# Altium schematic sheet power.SchDoc(power)
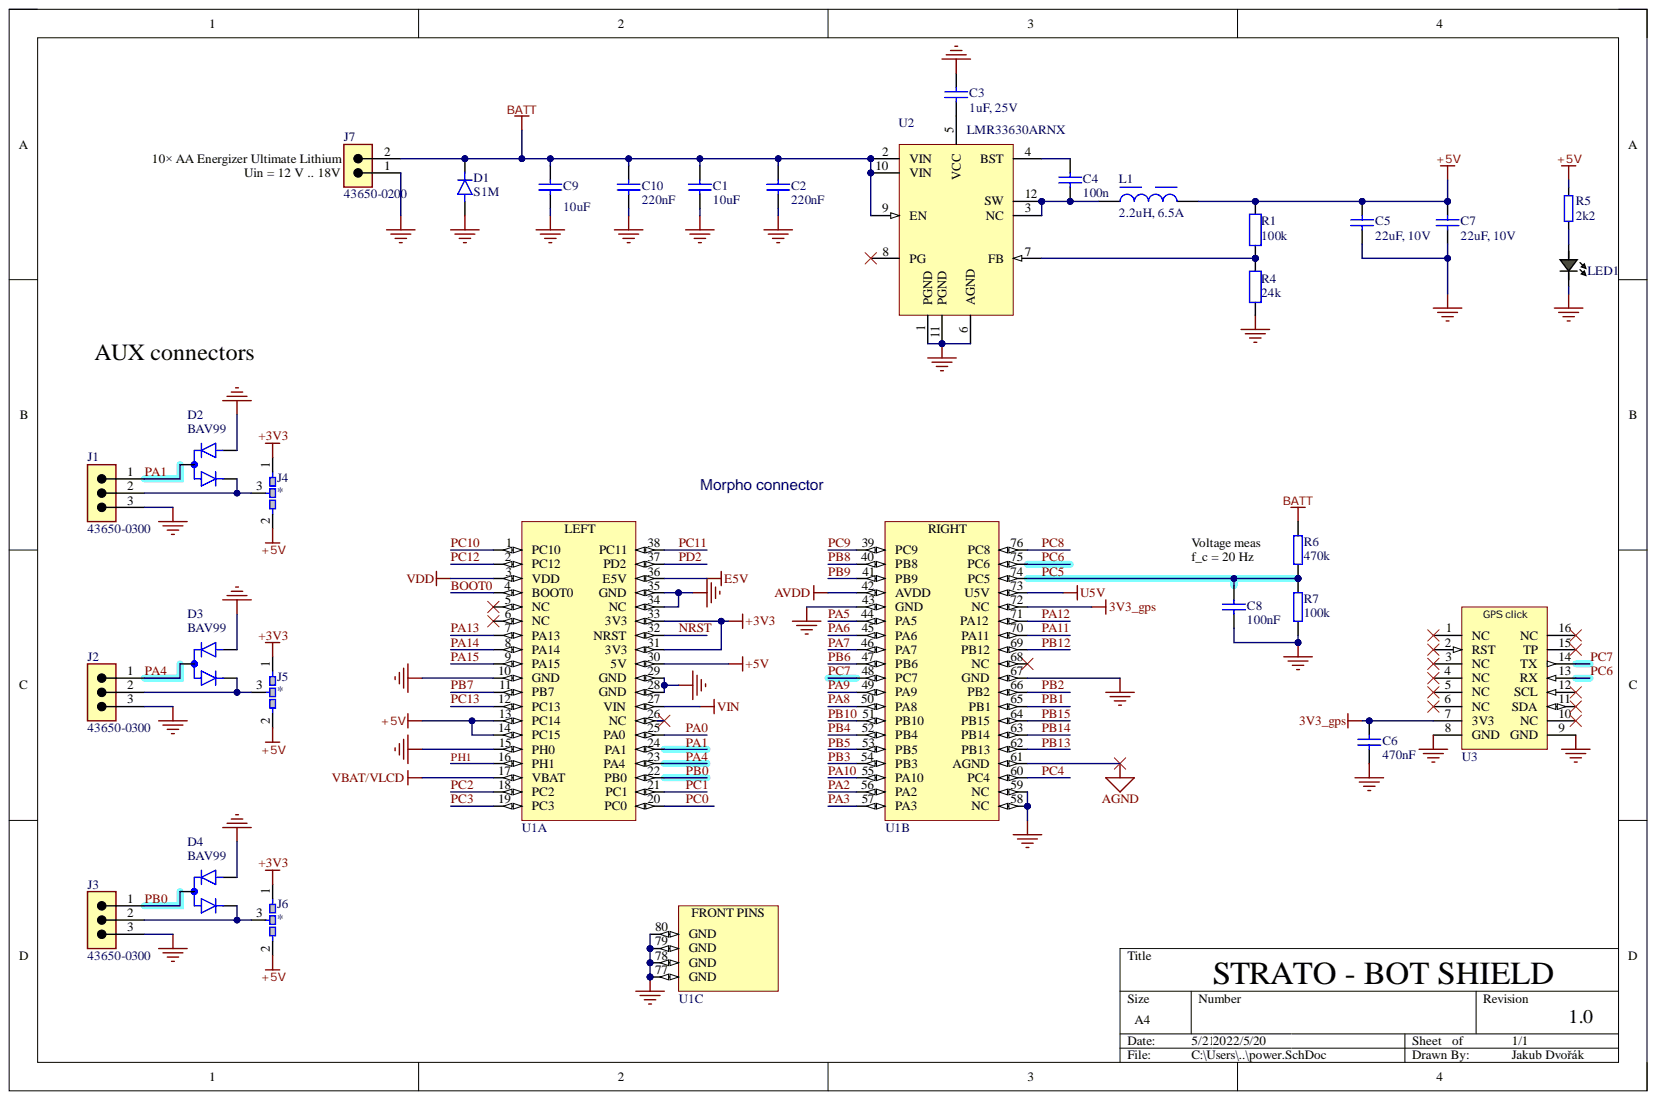
[diagram p0: power.SchDoc]

## Parts
C1  10uF  [WCAP-CSGP_1206_L3.2W1.6H1.6]
C2  220nF  [WCAP-CSGP_0603_L1.6W0.8H0.8_Reflow]
C3  1uF, 25V  [WCAP-CSGP_0603_L1.6W0.8H0.8_Reflow]
C4  100n  [WCAP-CSGP_0603_L1.6W0.8H0.8_Reflow]
C5  22uF, 10V  [WCAP-CSGP_0805_L2W1.25H1.25]
C6  470nF  [WCAP-CSGP_0805_L2W1.25H1.25]
C7  22uF, 10V  Rated Voltage: 10V  [WCAP-CSGP_0805_L2W1.25H1.25]
C8  100nF  [WCAP-CSGP_0805_L2W1.25H0.8_Reflow]
C9  10uF  [WCAP-CSGP_1206_L3.2W1.6H1.6]
C10  220nF  [WCAP-CSGP_0603_L1.6W0.8H0.8_Reflow]
D1  S1M  [DIOM5127X23N(SMA)]
D2  BAV99  [SOT95P230X110-3N(SOT23)]
D3  BAV99  [SOT95P230X110-3N(SOT23)]
D4  BAV99  [SOT95P230X110-3N(SOT23)]
J1  43650-0300  [MOLEX_43650-0300]
J2  43650-0300  [MOLEX_43650-0300]
J3  43650-0300  [MOLEX_43650-0300]
J4  (no value)  [JUMPER3_SMT_1LAYER]  (no BOM)
J5  (no value)  [JUMPER3_SMT_1LAYER]  (no BOM)
J6  (no value)  [JUMPER3_SMT_1LAYER]  (no BOM)
J7  43650-0200  [MOLEX_43650-0200]
L1  2.2uH, 6.5A  Rated Current: 6.5A  [WE-LHMI_7030]
LED1  green  [0805LEDG]  (value not drawn)
R1  value 100k  [RES_0603]  (hidden comment: Thick film)
R4  value 24k  [RES_0603]  (hidden comment: Thick film)
R5  value 2k2  [RES_0603]  (hidden comment: Thick film)
R6  470k  [RESC2012X06N(SMR0805)]
R7  100k  [RESC2012X06N(SMR0805)]
U1  NUCLEO F466 MORPHO LEFT  [Nucleo_bot_pads]  (value not drawn)  (parts A,B,C)
U2  LMR33630ARNX  [QFN50P200X300X100-12N]
U3  GPS Click  [GPS click - no legs]  (value not drawn)

## Nets
3V3_gps: C6.2 J4.1 J5.1 J6.1 U1.31(3V3) U1.33(3V3) U1.72(NC) U3.7(3V3)
+5V: C5.2 C7.2 J4.2 J5.2 J6.2 L1.2 R1.2 R5.2 U1.13(PC14) U1.14(PC15) U1.30(5V)
AGND: U1.61(AGND)
AVDD: U1.42(AVDD)
BATT: C1.2 C2.2 C9.2 C10.2 D1.1 J7.2 R6.2 U2.2(VIN) U2.9(EN) U2.10(VIN)
E5V: U1.36(E5V)
GND: C1.1 C2.1 C3.2 C5.1 C6.1 C7.1 C8.1 C9.1 C10.1 D1.2 D2.1 D3.1 D4.1 J1.3 J2.3 J3.3 J7.1 LED1.K R4.1 R7.1 U1.10(GND) U1.15(PH0) U1.28(GND) U1.29(GND) U1.34(NC) U1.35(GND) U1.43(GND) U1.58(NC) U1.59(NC) U1.67(GND) U1.77(GND) U1.78(GND) U1.79(GND) U1.80(GND) U2.1(PGND) U2.6(AGND) U2.11(PGND) U3.8(GND) U3.9(GND)
U5V: U1.73(U5V)
VBAT/VLCD: U1.17(VBAT)
VDD: U1.3(VDD)
VIN: U1.27(VIN)
BOOT0: U1.4(BOOT0)
NRST: U1.32(NRST)
PA0: U1.25(PA0)
PA1: D2.3 J1.1 U1.24(PA1)
PA2: U1.56(PA2)
PA3: U1.57(PA3)
PA4: D3.3 J2.1 U1.23(PA4)
PA5: U1.44(PA5)
PA6: U1.45(PA6)
PA7: U1.46(PA7)
PA8: U1.50(PA8)
PA9: U1.49(PA9)
PA10: U1.55(PA10)
PA11: U1.70(PA11)
PA12: U1.71(PA12)
PA13: U1.7(PA13)
PA14: U1.8(PA14)
PA15: U1.9(PA15)
PB0: D4.3 J3.1 U1.22(PB0)
PB1: U1.65(PB1)
PB2: U1.66(PB2)
PB3: U1.54(PB3)
PB4: U1.52(PB4)
PB5: U1.53(PB5)
PB6: U1.47(PB6)
PB7: U1.11(PB7)
PB8: U1.40(PB8)
PB9: U1.41(PB9)
PB10: U1.51(PB10)
PB12: U1.69(PB12)
PB13: U1.62(PB13)
PB14: U1.63(PB14)
PB15: U1.64(PB15)
PC0: U1.20(PC0)
PC1: U1.21(PC1)
PC2: U1.18(PC2)
PC3: U1.19(PC3)
PC4: U1.60(PC4)
PC5: C8.2 R6.1 R7.2 U1.74(PC5)
PC6: U1.75(PC6) U3.13(RX)
PC7: U1.48(PC7) U3.14(TX)
PC8: U1.76(PC8)
PC9: U1.39(PC9)
PC10: U1.1(PC10)
PC11: U1.38(PC11)
PC12: U1.2(PC12)
PC13: U1.12(PC13)
PD2: U1.37(PD2)
PH1: U1.16(PH1)
NetC3_1: C3.1 U2.5(VCC)
NetC4_1: C4.1 L1.1 U2.3(NC) U2.12(SW)
NetC4_2: C4.2 U2.4(BST)
NetD2_2: D2.2 J1.2 J4.3
NetD3_2: D3.2 J2.2 J5.3
NetD4_2: D4.2 J3.2 J6.3
NetLED1_A: LED1.A R5.1
NetR1_1: R1.1 R4.2 U2.7(FB)

Not connected: U1 5(NC) 6(NC) 26(NC) 68(NC); U2 8(PG); U3 1(NC) 2(RST) 3(NC) 4(NC) 5(NC) 6(NC) 10(NC) 11(SDA) 12(SCL) 15(TP) 16(NC)

## Library data of the parts
C1 C9 = 885012108022: WCAP-CSGP Multilayer Ceramic Chip Capacitor, General Purpose, size 1206, X5R, 10uF, 50V; Manufacturer: Wurth Elektronik; Capacitance Tolerance: +/-10%; Dissipation Factor: 10%; Isolation Resistance: 0.005GOhm; Technical Reference: X5R1206106K050DFCT10000; Capacitance: 10uF; Ceramic Type: X5R; Component Kind: Standard; ComponentLink1Description: Manufacturer Link; ComponentLink1URL: http://www.we-online.de; ComponentLink2Description: Datasheet; ComponentLink2URL: https://www.we-online.com/catalog/datasheet/885012108022.pdf; Height: 1.6mm; Length: 3.2mm; Library Name: Altium_WCAP-CSGP (rev22a).IntLib; Manufacturer Part Number: 885012108022; Mounting Technology: SMT; Operating Temperature max.: 85C; Operating Temperature min.: -55C; Packaging: Tape and Reel; Rated Voltage: 50V; Size: 1206; Width: 1.6mm
C2 C10 = 885012206125: WCAP-CSGP Multilayer Ceramic Chip Capacitor, General Purpose, size 0603, X7R, 220nF, 50V; Manufacturer: Wurth Elektronik; Capacitance Tolerance: +/-5%; Dissipation Factor: 10%; Isolation Resistance: 2.3GOhm; Technical Reference: X7R0603224J050DFCT10000; Capacitance: 220nF; Ceramic Type: X7R; Component Kind: Standard; ComponentLink1Description: Manufacturer Link; ComponentLink1URL: http://www.we-online.de; ComponentLink2Description: Datasheet; ComponentLink2URL: https://www.we-online.com/catalog/datasheet/885012206125.pdf; Height: 0.8mm; Length: 1.6mm; Library Name: Altium_WCAP-CSGP (rev22a).IntLib; Manufacturer Part Number: 885012206125; Mounting Technology: SMT; Operating Temperature max.: 125C; Operating Temperature min.: -55C; Packaging: Tape and Reel; Rated Voltage: 50V; Size: 0603; Width: 0.8mm
C3 = 885012206076: WCAP-CSGP Multilayer Ceramic Chip Capacitor, General Purpose, size 0603, X7R, 1uF, 25V; Manufacturer: Wurth Elektronik; Capacitance Tolerance: +/-10%; Dissipation Factor: 10%; Isolation Resistance: 0.5GOhm; Technical Reference: X7R0603105K025DFCT10000; Capacitance: 1uF; Ceramic Type: X7R; Component Kind: Standard; ComponentLink1Description: Manufacturer Link; ComponentLink1URL: http://www.we-online.de; ComponentLink2Description: Datasheet; ComponentLink2URL: https://www.we-online.com/catalog/datasheet/885012206076.pdf; Height: 0.8mm; Length: 1.6mm; Library Name: Altium_WCAP-CSGP (rev22a).IntLib; Manufacturer Part Number: 885012206076; Mounting Technology: SMT; Operating Temperature max.: 125C; Operating Temperature min.: -55C; Packaging: Tape and Reel; Rated Voltage: 25V; Size: 0603; Width: 0.8mm
C4 = 885012206071R: WCAP-CSGP Multilayer Ceramic Chip Capacitor, General Purpose, size 0603, X7R, 100nF, 25V; Manufacturer: Wurth Elektronik; Capacitance Tolerance: +/-10%; Dissipation Factor: 3.5%; Isolation Resistance: 5GOhm; Technical Reference: X7R0603104K025DFCT20000; Capacitance: 100nF; Ceramic Type: X7R; Component Kind: Standard; ComponentLink1Description: Manufacturer Link; ComponentLink1URL: http://www.we-online.de; ComponentLink2Description: Datasheet; ComponentLink2URL: https://www.we-online.com/catalog/datasheet/885012206071R.pdf; Height: 0.8mm; Length: 1.6mm; Library Name: Altium_WCAP-CSGP (rev22a).IntLib; Manufacturer Part Number: 885012206071R; Mounting Technology: SMT; Operating Temperature max.: 125C; Operating Temperature min.: -55C; Packaging: Tape and Reel; Rated Voltage: 25V; Size: 0603; Width: 0.8mm
C5 C7 = 885012107011: WCAP-CSGP Multilayer Ceramic Chip Capacitor, General Purpose, size 0805, X5R, 22uF, 10V; Manufacturer: Wurth Elektronik; Capacitance Tolerance: +/-20%; Dissipation Factor: 10%; Isolation Resistance: 0.005GOhm; Technical Reference: X5R0805226M010DFCT10000; Capacitance: 22uF; Ceramic Type: X5R; Component Kind: Standard; ComponentLink1Description: Manufacturer Link; ComponentLink1URL: http://www.we-online.de; ComponentLink2Description: Datasheet; ComponentLink2URL: https://www.we-online.com/catalog/datasheet/885012107011.pdf; Height: 1.25mm; Length: 2mm; Library Name: Altium_WCAP-CSGP (rev22a).IntLib; Manufacturer Part Number: 885012107011; Mounting Technology: SMT; Operating Temperature max.: 85C; Operating Temperature min.: -55C; Packaging: Tape and Reel; Size: 0805; Width: 1.25mm
  C5: Rated Voltage: 10V
C6 = 885012207102: WCAP-CSGP Multilayer Ceramic Chip Capacitor, General Purpose, size 0805, X7R, 470nF, 50V; Manufacturer: Wurth Elektronik; Capacitance Tolerance: +/-10%; Dissipation Factor: 3%; Isolation Resistance: 1.1GOhm; Technical Reference: X7R0805474K050DFCT10000; Capacitance: 470nF; Ceramic Type: X7R; Component Kind: Standard; ComponentLink1Description: Manufacturer Link; ComponentLink1URL: http://www.we-online.de; ComponentLink2Description: Datasheet; ComponentLink2URL: https://www.we-online.com/catalog/datasheet/885012207102.pdf; Height: 1.25mm; Length: 2mm; Library Name: Altium_WCAP-CSGP (rev22a).IntLib; Manufacturer Part Number: 885012207102; Mounting Technology: SMT; Operating Temperature max.: 125C; Operating Temperature min.: -55C; Packaging: Tape and Reel; Rated Voltage: 50V; Size: 0805; Width: 1.25mm
C8 = 885012207098R: WCAP-CSGP Multilayer Ceramic Chip Capacitor, General Purpose, size 0805, X7R, 100nF, 50V; Manufacturer: Wurth Elektronik; Capacitance Tolerance: +/-10%; Dissipation Factor: 2.5%; Isolation Resistance: 5GOhm; Technical Reference: X7R0805104K050DFCT20000; Capacitance: 100nF; Ceramic Type: X7R; Component Kind: Standard; ComponentLink1Description: Manufacturer Link; ComponentLink1URL: http://www.we-online.de; ComponentLink2Description: Datasheet; ComponentLink2URL: https://www.we-online.com/catalog/datasheet/885012207098R.pdf; Height: 0.8mm; Length: 2mm; Library Name: Altium_WCAP-CSGP (rev22a).IntLib; Manufacturer Part Number: 885012207098R; Mounting Technology: SMT; Operating Temperature max.: 125C; Operating Temperature min.: -55C; Packaging: Tape and Reel; Rated Voltage: 50V; Size: 0805; Width: 1.25mm
D1 = ED+S1MXX0: D; Si; 1000V; 1A; 1x;; Database Table Name: BTL_DbLib; Component Kind: Standard; KK: ED+S1MXX0; Library Name: altium_sql.DbLib; Simulation: DI_S1M
J1-J3 = EJ-0436500300XX0: Con; Micro Fit 3.0; 3x; PCB; Right angle; 250V; 5A; Male; Tin; ??;; Manufacturer: Molex: 436500300; Component_Class: Connector; Current_Rating_A: 5; Database Table Name: BTL_DbLib; Multiplicity: 3; Status_I: Production; Status_II: Active; Voltage_Rating_V: 250; Angle: Right angle; Component Kind: Standard; ComponentLink1Description: Helios; ComponentLink1URL: heliosgreen://v1/hegMT/5-MT/Classes(343)/Folders/OpenForm?RecordNumbers=[10524933]; ComponentLink2Description: EJ-0436500300XX0_Molex_DRW_100.pdf; ComponentLink3Description: EJ-0436500300XX0_MolexEN100.pdf; Connector_Family: Micro Fit 3.0; Gender: Male; KK: EJ-0436500300XX0; Library Name: altium_sql.DbLib; Mounting_Style: PCB; Plating: Tin; SMD: 0; System: Connector; Value: 43650-0300; ComponentLink2URL: I:\datasheets\EJ-0436500300XX0_Molex_DRW_100.pdf; ComponentLink3URL: I:\datasheets\EJ-0436500300XX0_MolexEN100.pdf
J4-J6 = JUMPER_3P: Soldering Jumper, 3 Pins; 01_HODNOTA/POPIS: SHORT CUT; 03_MERITKO: 0.3mm izol. mezera; 04_DOPLNKOVY TEXT: 0.3mm - MOTIV na DPS; Library Name: Production_Library.IntLib; SMD: 1; Author: JM 1.9.2015
J7 = EJ-43650-0200XX0: Con; Micro Fit 3.0; 2x; PCB; Right angle; 600V; 5A; Male; Nickel; ??;; Manufacturer: MOLEX: 43650-0200; Component_Class: Connector; Current_Rating_A: 5; Database Table Name: BTL_DbLib; Multiplicity: 2; Status_I: Production; Status_II: Active; Voltage_Rating_V: 600; Angle: Right angle; Component Kind: Standard; ComponentLink1Description: Helios; ComponentLink1URL: heliosgreen://v1/hegMT/5-MT/Classes(343)/Folders/OpenForm?RecordNumbers=[11652171]; ComponentLink2Description: EJ-43650-0200XX0_MolexEN100.pdf; Connector_Family: Micro Fit 3.0; Gender: Male; KK: EJ-43650-0200XX0; Library Name: altium_sql.DbLib; Mounting_Style: PCB; Plating: Nickel; SMD: 0; System: Connector; Value: 43650-0200; ComponentLink2URL: I:\datasheets\EJ-43650-0200XX0_MolexEN100.pdf
L1 = 74437346022: WE-LHMI SMT Power Inductor, size 7030, 2.2uH, 6.5A; Manufacturer: Wurth Elektronik; DC Resistance max.: 20mOhm; DC Resistance typ.: 18mOhm; Saturation Current @10%: 10A; Saturation Current @30%: 19.1A; Self Resonant Frequency: 32MHz; Component Kind: Standard; ComponentLink1Description: Manufacturer; ComponentLink1URL: http://www.we-online.de; ComponentLink2Description: Datasheet; ComponentLink2URL: https://www.we-online.com/catalog/datasheet/74437346022.pdf; Height: 2.8mm; Inductance: 2.2uH; Length: 6.6mm; Library Name: ind-WE-LHMI (rev21a).IntLib; Manufacturer Part Number: 74437346022; Mounting Technology: SMT; Operating Temperature: -40 to +125degC; Packaging: Tape and Reel; Size: 7030; Width: 6.6mm
LED1 = LEDG_0805: Component Kind: Standard; Library Name: all_in_one_library.IntLib
R1 = RES_100k_5%_0603: 100000.00; Case [EIA]: 0603; Case [Metric]: 1608; Power Rating [W]: 0.1; Tolerance [%]: ±5; Component Kind: Standard; Library Name: all_in_one_library.IntLib; Value [Ω]: 100000.00
R4 = RES_24k_5%_0603: 24000.00; Case [EIA]: 0603; Case [Metric]: 1608; Power Rating [W]: 0.1; Tolerance [%]: ±5; Component Kind: Standard; Library Name: all_in_one_library.IntLib; Value [Ω]: 24000.00
R5 = RES_2k2_5%_0603: 2200.00; Case [EIA]: 0603; Case [Metric]: 1608; Power Rating [W]: 0.1; Tolerance [%]: ±5; Component Kind: Standard; Library Name: all_in_one_library.IntLib; Value [Ω]: 2200.00
R6 = ER+470K0.1WXXF: R; 470k; 0.125W; 1%; 150V; Thick Film; ±100ppm/K; 1x;; Manufacturer: YAGEO: RC0805FR-07470KL;;AVX: CR21-4703F-T;;BC Components: MCU0805-50 1% P5 470k;;PANASONIC: ERJ 6EN F 4703 V;;HaS Lanskroun: CMF0805 470k 1% 100ppm/K; Component_Class: Resistor; Database Table Name: BTL_DbLib; Multiplicity: 1; Power_Rating_W: 0.125; Resistance_Ohm: 470000; Status_I: Production; Status_II: Active; Temperature_Coefficient_PPMpK: ±100; Voltage_Rating_V: 150; Component Kind: Standard; ComponentLink1Description: Helios; ComponentLink1URL: heliosgreen://v1/hegMT/5-MT/Classes(343)/Folders/OpenForm?RecordNumbers=[381583]; ComponentLink2Description: ER+0.1WXXF_Yageo.pdf; KK: ER+470K0.1WXXF; Library Name: altium_sql.DbLib; Resistor_Type: Thick Film; Simulation: Simple; SMD: 1; Tolerance_Pct: 1; Value: 470k; ComponentLink2URL: i:\DataSheets\ER+0.1WXXF_Yageo.pdf
R7 = ER+100K0.1WXXF: R; 100k; 0.125W; 1%; 150V; Thick Film; ±100ppm/K; 1x;; Manufacturer: YAGEO: RC0805FR-10100KL; Component_Class: Resistor; Database Table Name: BTL_DbLib; Multiplicity: 1; Power_Rating_W: 0.125; Resistance_Ohm: 100000; Status_I: Production; Status_II: Active; Temperature_Coefficient_PPMpK: ±100; Voltage_Rating_V: 150; Component Kind: Standard; ComponentLink1Description: Helios; ComponentLink1URL: heliosgreen://v1/hegMT/5-MT/Classes(343)/Folders/OpenForm?RecordNumbers=[381474]; ComponentLink2Description: ER+0.1WXXF_Yageo.pdf; KK: ER+100K0.1WXXF; Library Name: altium_sql.DbLib; Resistor_Type: Thick Film; Simulation: Simple; SMD: 1; Tolerance_Pct: 1; Value: 100k; ComponentLink2URL: i:\DataSheets\ER+0.1WXXF_Yageo.pdf
U2 = EU+LMR33630ARNXXX0: IC; SMPS Regulator/Controller; 3.8V; 36V; 1x;; Manufacturer: Texas Instruments: LMR33630ARNXR;;Texas Instruments: LMR33630ARNXT; Database Table Name: BTL_DbLib; Multiplicity: 1; Note: 3-A Synchronous Step-Down Voltage Converter; Status_I: Production; Status_II: Active; Vmax_V: 36; Vmin_V: 3.8; Component Kind: Standard; Component_Class: IC; ComponentLink1Description: Helios; ComponentLink1URL: heliosgreen://v1/hegMT/5-MT/Classes(343)/Folders/OpenForm?RecordNumbers=[12689791]; ComponentLink2Description: EU+LMR33630ARNXXX0_TexasInstrumentsEN100; IC_Type: SMPS Regulator/Controller; KK: EU+LMR33630ARNXXX0; Library Name: altium_sql.DbLib; SMD: 1; Value: LMR33630ARNX; ComponentLink2URL: I:\DataSheets\EU+LMR33630ARNXXX0_TexasInstrumentsEN100.pdf
D2-D4 = BAV99: Component Kind: Standard; ComponentLink1Description: New link; ComponentLink1URL: C:\Users\Public\Documents\Altium\DSH\EDD+BAV99XX0_OnsemiEN100.PDF; Library Name: Kubikova integrovana knihovna .IntLib
U1 = NUCLEO F466 MORPHO LEFT: Component Kind: Standard; Library Name: Kubikova ultra knihovna.SchLib
U3 = GPS Click: Component Kind: Standard; Library Name: Kubikova ultra knihovna.SchLib
